# Altium schematic sheet thermocouple_amp.SchDoc
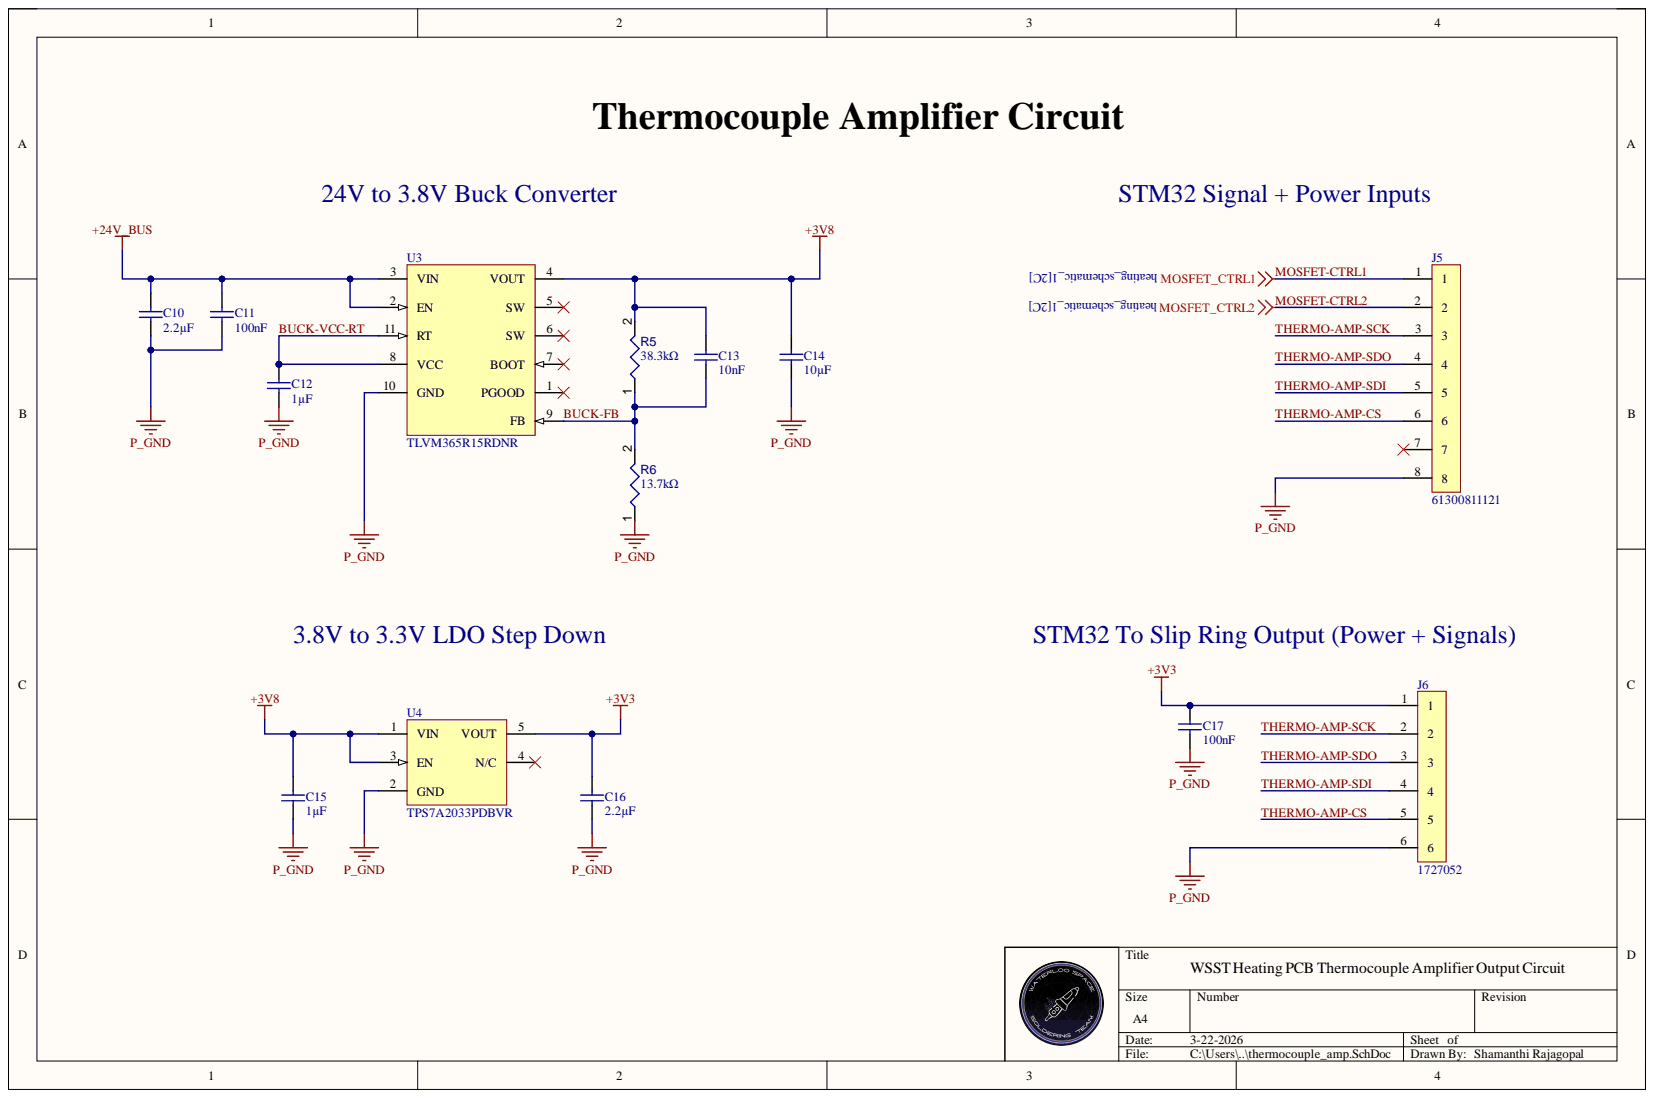
[diagram p0: thermocouple_amp.SchDoc]

## Parts
C10  value 2.2µF  (hidden comment: GRM32ER72A225KA35L)
C11  value 100nF  (hidden comment: GRM188R72A104KA35D)
C12  value 1µF  (hidden comment: EMK107B7105KA-T)
C13  value 10nF  (hidden comment: CC0402KRX5R6BB103)
C14  value 10µF  (hidden comment: C0805C106K8PACTU)
C15  value 1µF  (hidden comment: CL10B105KP8NNNC)
C16  value 2.2µF  (hidden comment: CL10B225KP8NNNC)
C17  value 100nF  (hidden comment: C0603C104K5RACTU)
J5  61300811121
J6  1727052
R5  R  value 38.3kΩ
R6  R  value 13.7kΩ
U3  TLVM365R15RDNR
U4  TPS7A2033PDBVR

## Nets
+3V3: C16.2 C17.2 J6.1 U4.5(VOUT)
+3V8: C13.2 C14.2 C15.2 R5.2 U3.4(VOUT) U4.1(VIN) U4.3(EN)
+24V_BUS: C10.2 C11.2 U3.2(EN) U3.3(VIN)
P_GND: C10.1 C11.1 C12.1 C14.1 C15.1 C16.1 C17.1 J5.8 J6.6 R6.1 U3.10(GND) U4.2(GND)
BUCK-FB: C13.1 R5.1 R6.2 U3.9(FB)
BUCK-VCC-RT: C12.2 U3.8(VCC) U3.11(RT)
MOSFET-CTRL1: J5.1
MOSFET-CTRL2: J5.2
THERMO-AMP-CS: J5.6 J6.5
THERMO-AMP-SCK: J5.3 J6.2
THERMO-AMP-SDI: J5.5 J6.4
THERMO-AMP-SDO: J5.4 J6.3

Not connected: J5 7; U3 1(PGOOD) 5(SW) 6(SW) 7(BOOT); U4 4(N/C)
